# Altium schematic sheet nfsee.SchDoc
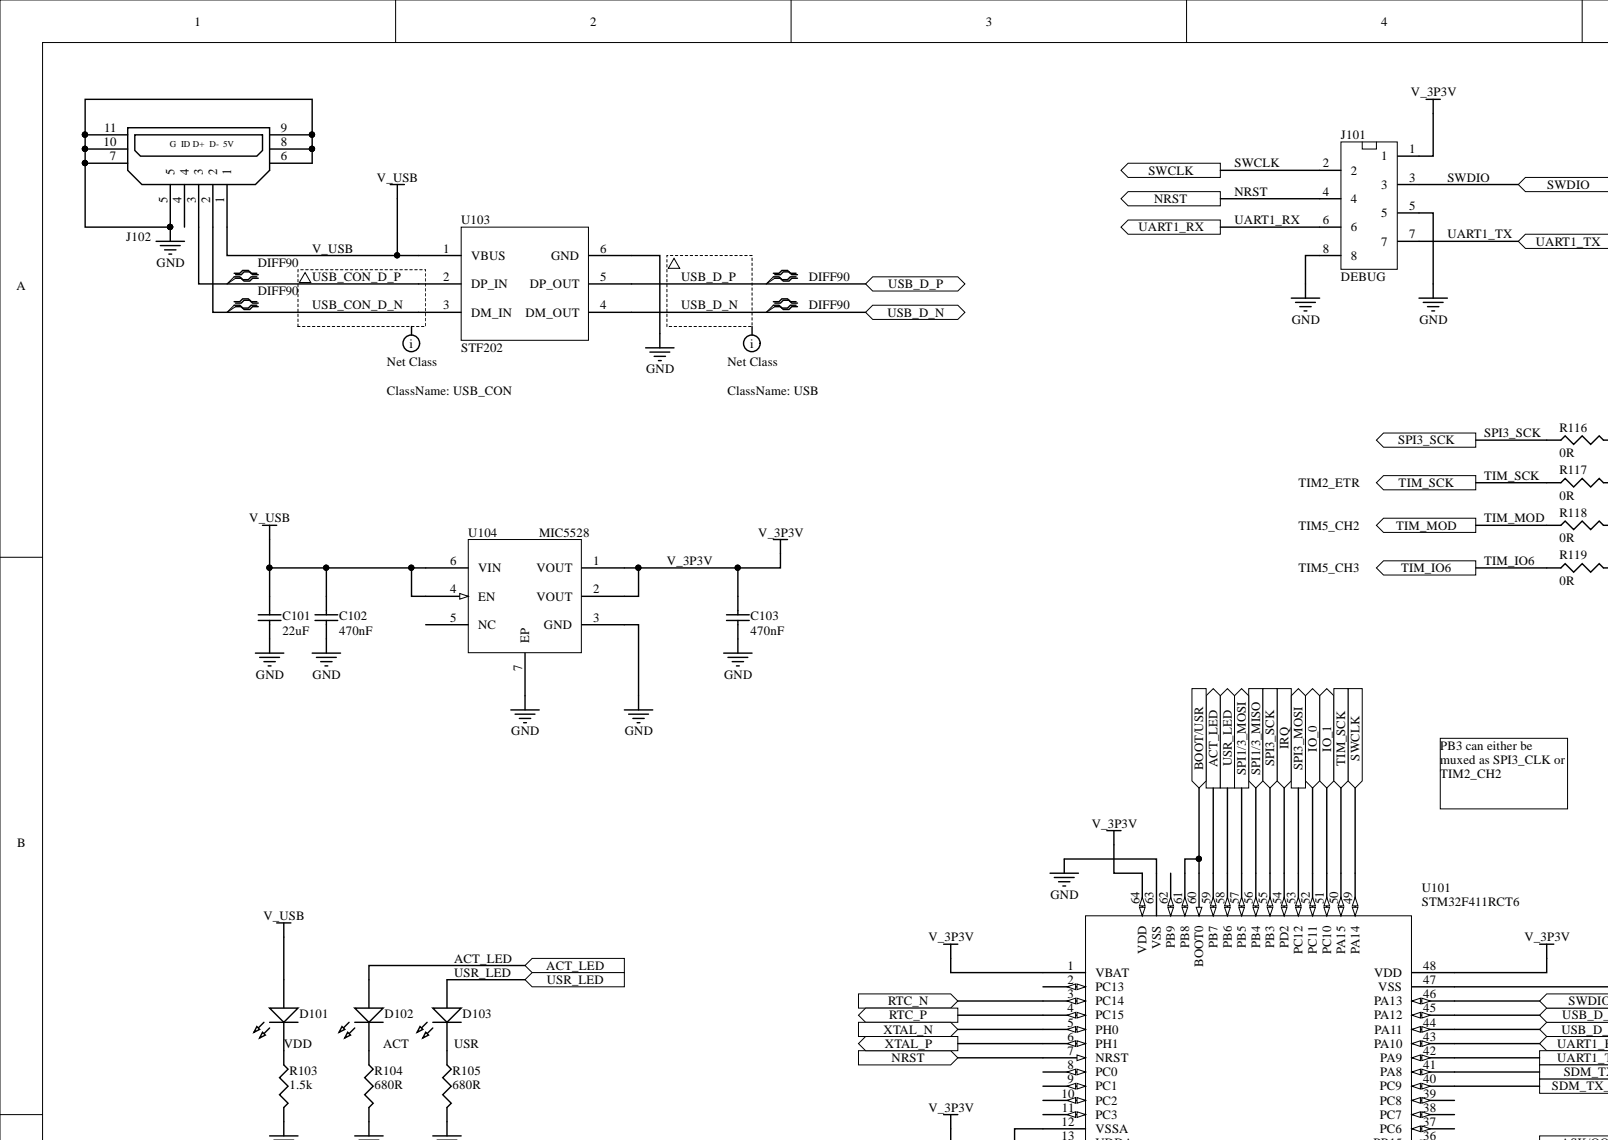
[diagram p0: nfsee.SchDoc, top left]
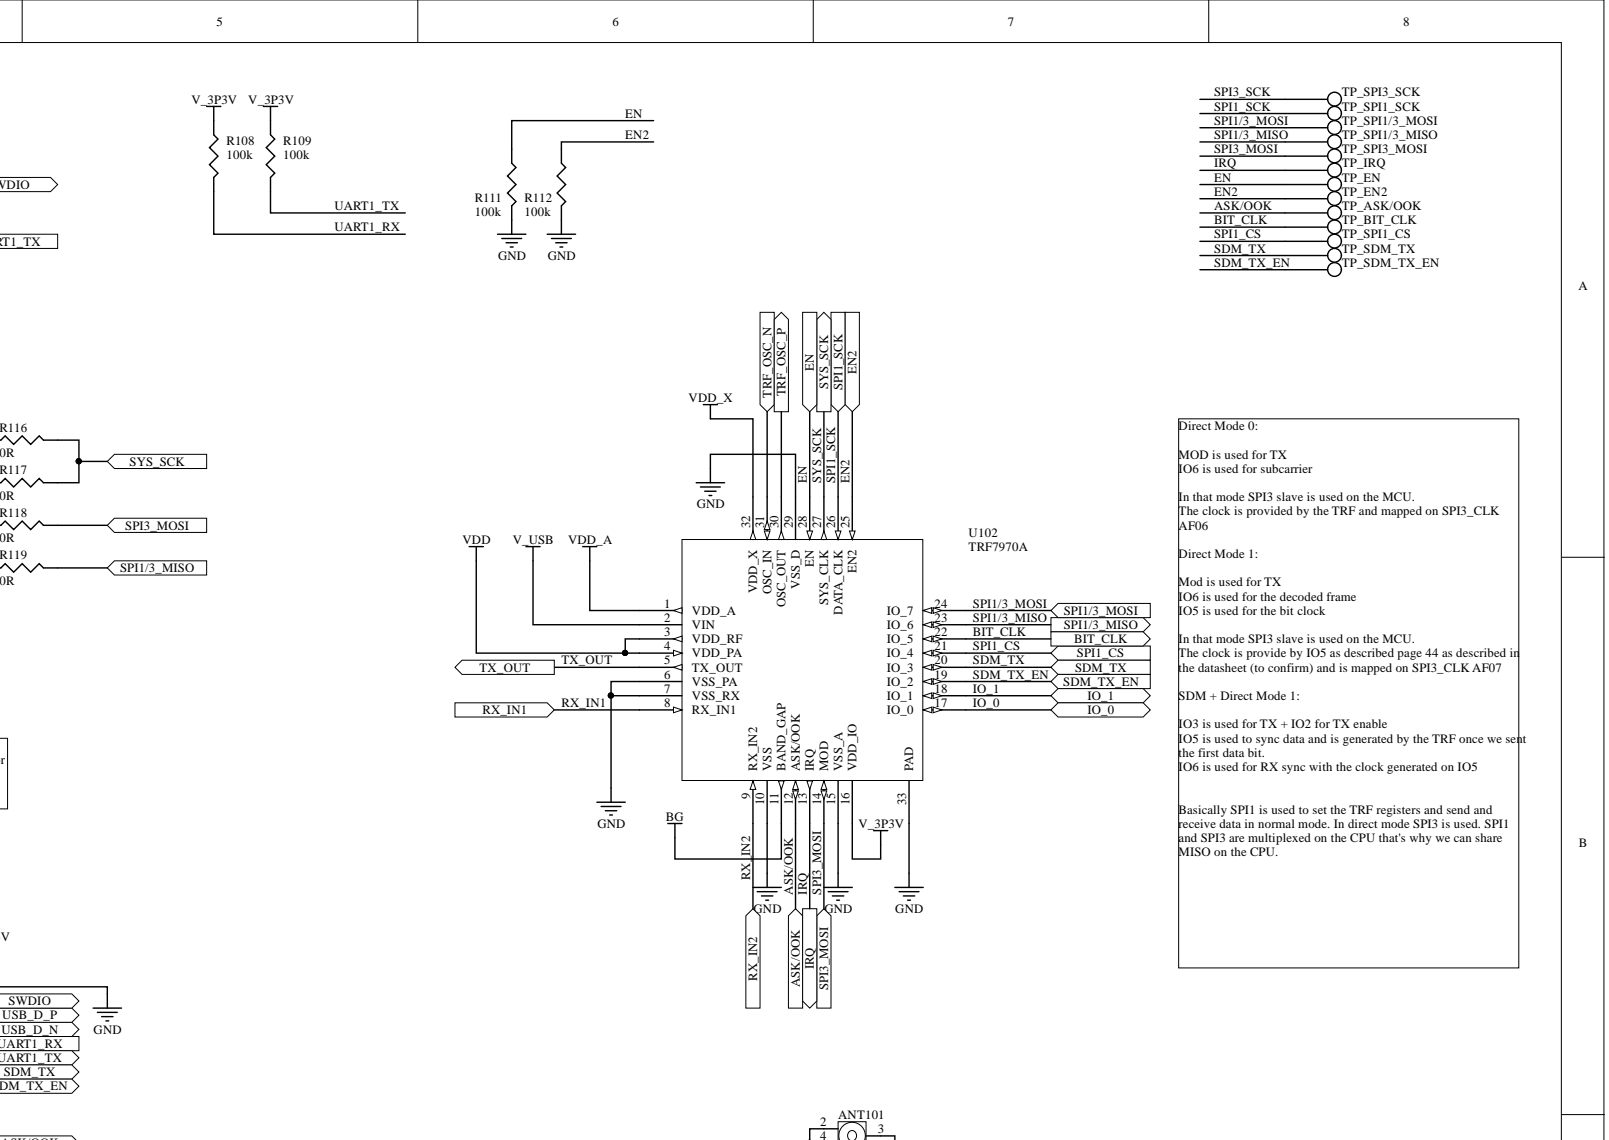
[diagram p1: nfsee.SchDoc, top right]
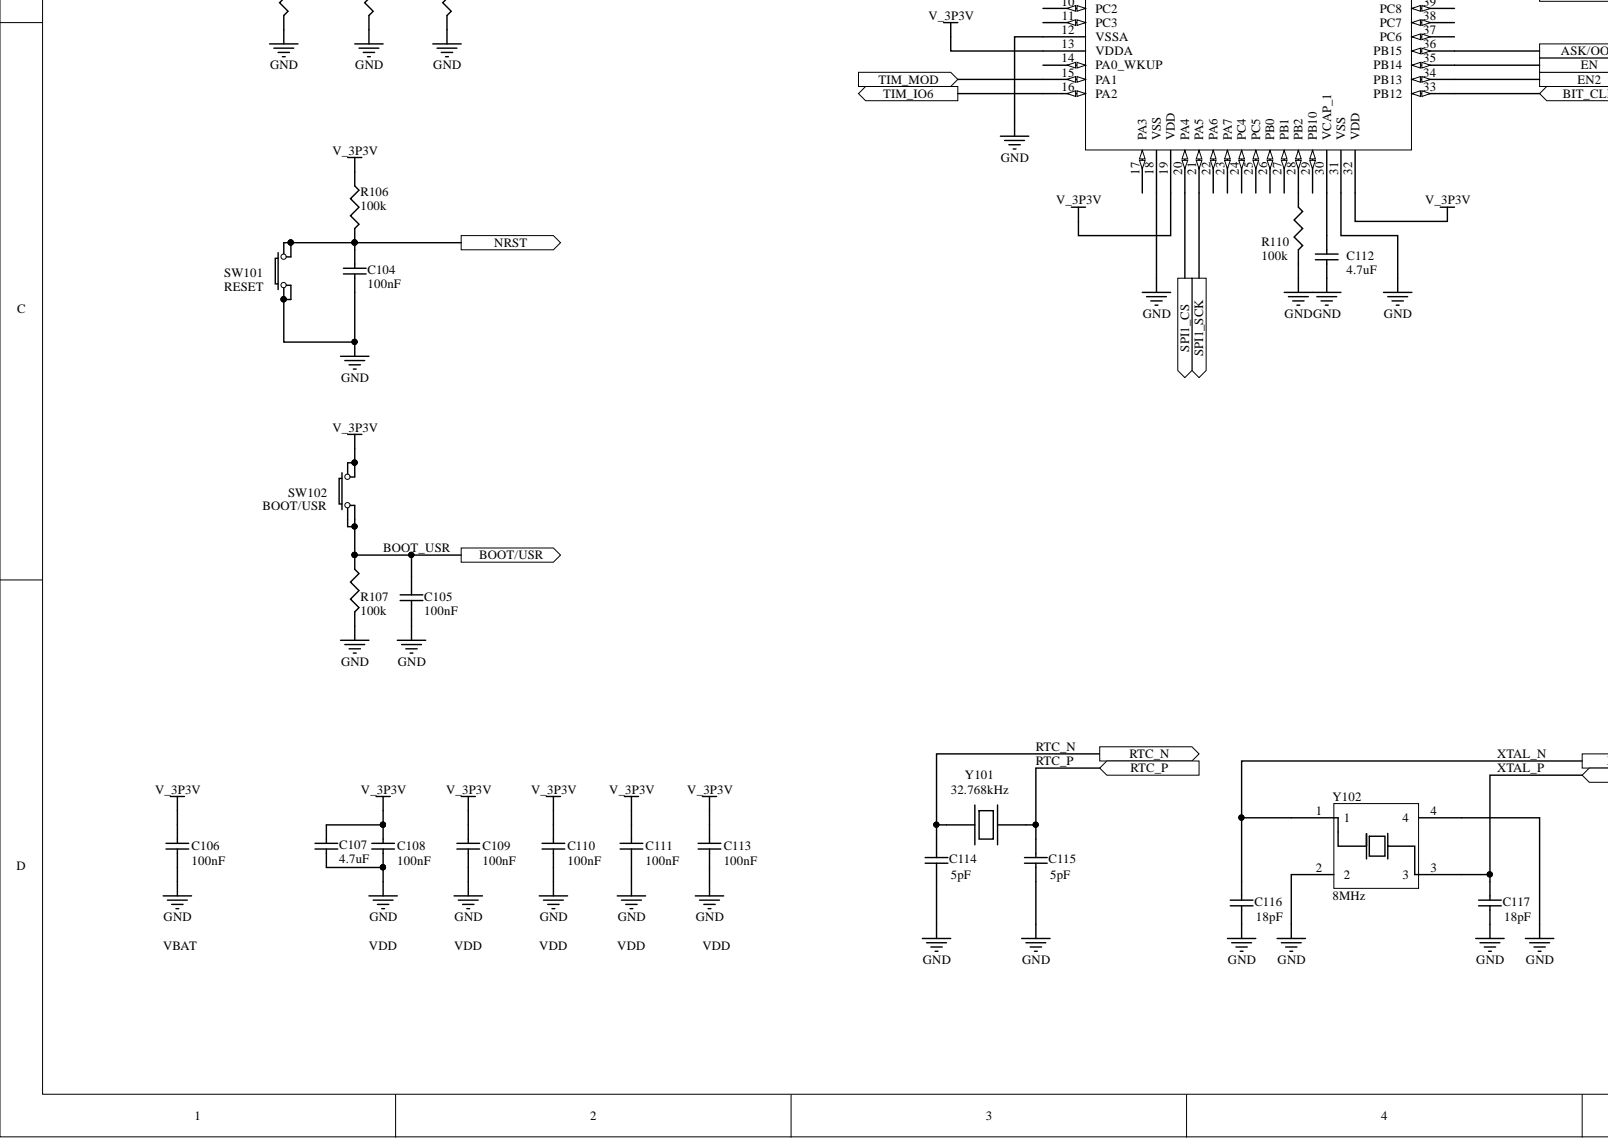
[diagram p2: nfsee.SchDoc, bottom left]
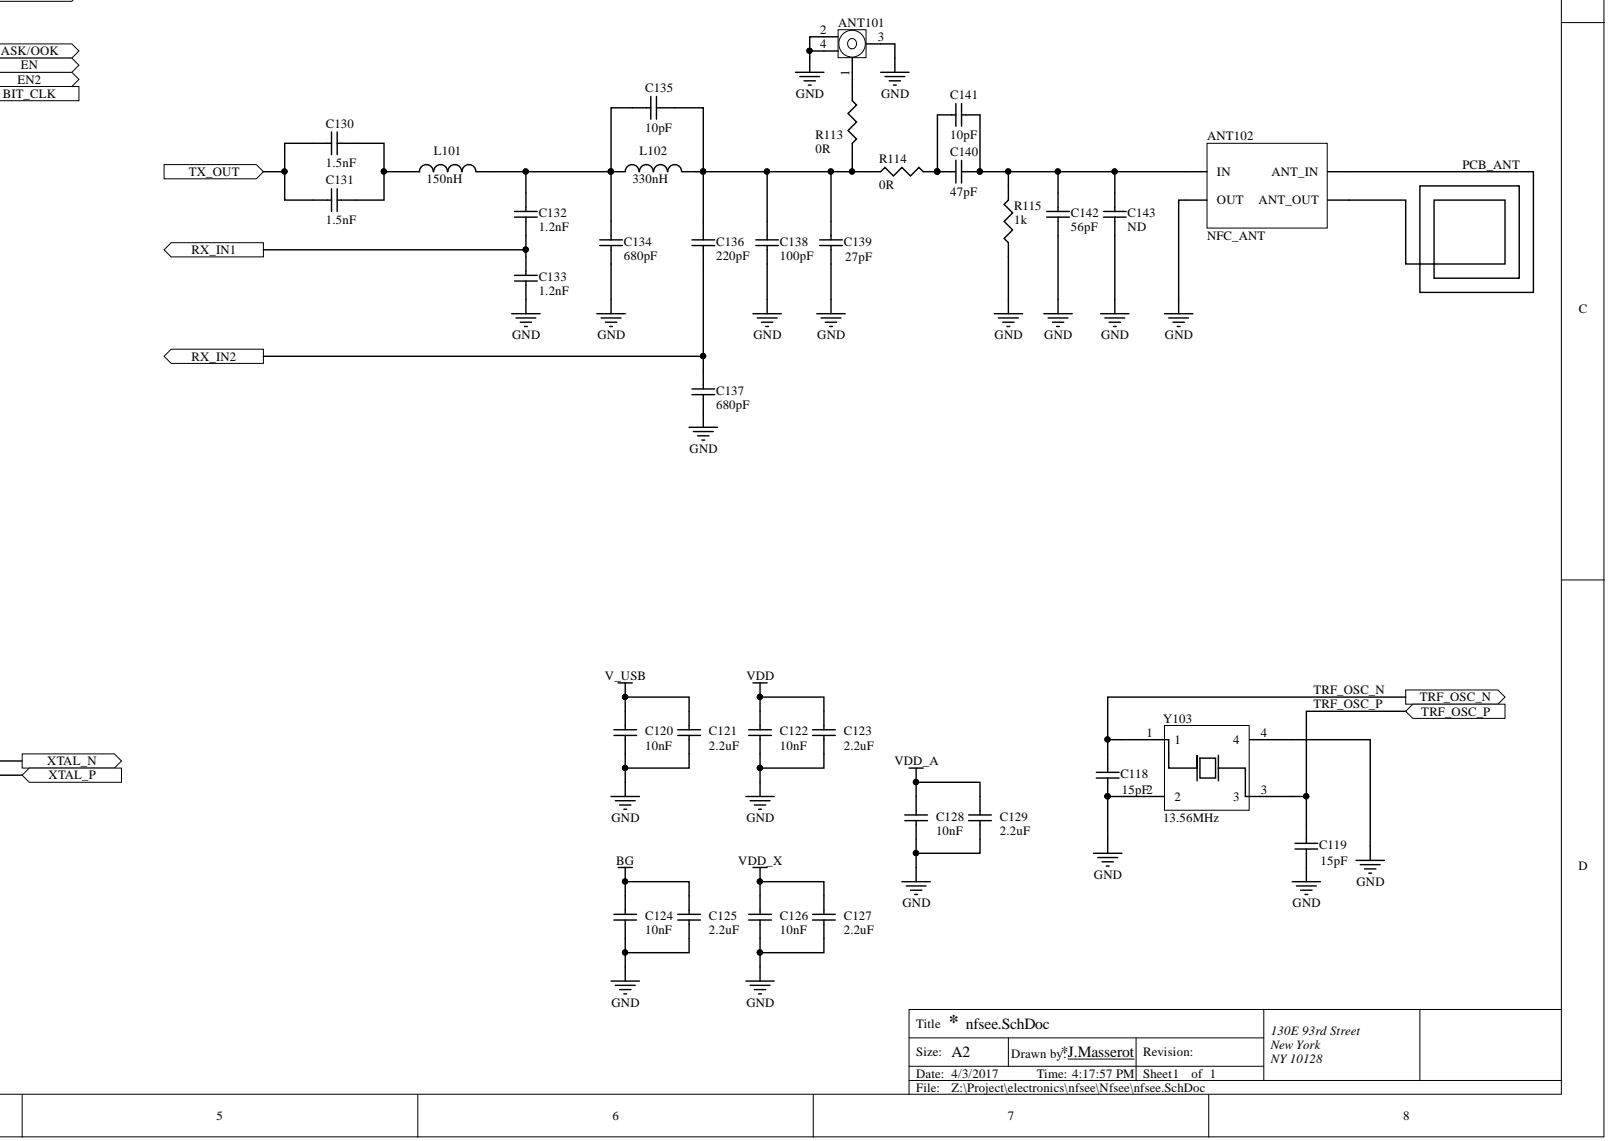
[diagram p3: nfsee.SchDoc, bottom right]

## Parts
ANT101  Antenna connector  [UFL_SMD]  (value not drawn)
ANT102  NFC_ANT  [NFC_ANT]
C101  22uF  [1608[0603]]
C102  470nF  [1608[0603]]
C103  470nF  [1608[0603]]
C104  100nF  [1608[0603]]
C105  100nF  [1608[0603]]
C106  100nF  [1608[0603]]
C107  4.7uF  [1608[0603]]
C108  100nF  [1608[0603]]
C109  100nF  [1608[0603]]
C110  100nF  [1608[0603]]
C111  100nF  [1608[0603]]
C112  4.7uF  [1608[0603]]
C113  100nF  [1608[0603]]
C114  5pF  [1608[0603]]
C115  5pF  [1608[0603]]
C116  18pF  [1608[0603]]
C117  18pF  [1608[0603]]
C118  15pF  [1608[0603]]
C119  15pF  [1608[0603]]
C120  10nF  [1608[0603]]
C121  2.2uF  [1608[0603]]
C122  10nF  [1608[0603]]
C123  2.2uF  [1608[0603]]
C124  10nF  [1608[0603]]
C125  2.2uF  [1608[0603]]
C126  10nF  [1608[0603]]
C127  2.2uF  [1608[0603]]
C128  10nF  [1608[0603]]
C129  2.2uF  [1608[0603]]
C130  1.5nF  [1608[0603]]
C131  1.5nF  [1608[0603]]
C132  1.2nF  [1608[0603]]
C133  1.2nF  [1608[0603]]
C134  680pF  [1608[0603]]
C135  10pF  [1608[0603]]
C136  220pF  [1608[0603]]
C137  680pF  [1608[0603]]
C138  100pF  [1608[0603]]
C139  27pF  [1608[0603]]
C140  47pF  [1608[0603]]
C141  10pF  [1608[0603]]
C142  56pF  [1608[0603]]
C143  ND  [1608[0603]]
D101  VDD  [J1-0603]
D102  ACT  [J1-0603]
D103  USR  [J1-0603]
J101  DEBUG  [MicroMaTch_8P]
J102  USB_micro  [MOLEX_47589-0001]  (value not drawn)
L101  150nH  [1608[0603]]
L102  330nH  [1608[0603]]
R103  1.5k  [J1-0603]
R104  680R  [J1-0603]
R105  680R  [J1-0603]
R106  100k  [J1-0603]
R107  100k  [J1-0603]
R108  100k  [J1-0603]
R109  100k  [J1-0603]
R110  100k  [J1-0603]
R111  100k  [J1-0603]
R112  100k  [J1-0603]
R113  0R  [J1-0603]
R114  0R  [J1-0603]
R115  1k  [J1-0603]
R116  0R  [J1-0603]
R117  0R  [J1-0603]
R118  0R  [J1-0603]
R119  0R  [J1-0603]
SW101  RESET  [KMR641NG]
SW102  BOOT/USR  [KMR641NG]
TP_ASK/OOK  Test point  [TP]  (value not drawn)
TP_BIT_CLK  Test point  [TP]  (value not drawn)
TP_EN  Test point  [TP]  (value not drawn)
TP_EN2  Test point  [TP]  (value not drawn)
TP_IRQ  Test point  [TP]  (value not drawn)
TP_SDM_TX  Test point  [TP]  (value not drawn)
TP_SDM_TX_EN  Test point  [TP]  (value not drawn)
TP_SPI1/3_MISO  Test point  [TP]  (value not drawn)
TP_SPI1/3_MOSI  Test point  [TP]  (value not drawn)
TP_SPI1_CS  Test point  [TP]  (value not drawn)
TP_SPI1_SCK  Test point  [TP]  (value not drawn)
TP_SPI3_MOSI  Test point  [TP]  (value not drawn)
TP_SPI3_SCK  Test point  [TP]  (value not drawn)
U101  STM32F411RCT6  [LQFP64]
U102  TRF7970A  [PVQFN-32N]
U103  STF202  [TSOP6]
U104  MIC5528  [DFN-6N]
Y101  32.768kHz  [XTAL2]
Y102  8MHz  [ABM3B]
Y103  13.56MHz  [CX2520DB]

## Ports
ACT_LED, ASK/OOK, BIT_CLK, BOOT/USR, EN, EN2, IO_0, IO_1, IRQ, NRST, RTC_N, RTC_P, RX_IN1, RX_IN2, SDM_TX, SDM_TX_EN, SPI1/3_MISO, SPI1/3_MOSI, SPI1_CS, SPI1_SCK, SPI3_MOSI, SPI3_SCK, SWCLK, SWDIO, SYS_SCK, TIM_IO6, TIM_MOD, TIM_SCK, TRF_OSC_N, TRF_OSC_P, TX_OUT, UART1_RX, UART1_TX, USB_D_N, USB_D_P, USR_LED, XTAL_N, XTAL_P

## Nets
BG: C124.2 C125.2 U102.11(BAND_GAP)
GND: ANT101.2 ANT101.3 ANT101.4 ANT102.2(OUT) C101.2 C102.2 C103.2 C104.1 C105.1 C106.1 C107.1 C108.1 C109.1 C110.1 C111.1 C112.1 C113.1 C114.1 C115.1 C116.1 C117.1 C118.1 C119.1 C120.1 C121.1 C122.1 C123.1 C124.1 C125.1 C126.1 C127.1 C128.1 C129.1 C133.2 C134.2 C137.2 C138.2 C139.1 C142.2 C143.2 J101.5 J101.8 J102.5 J102.6 J102.7 J102.8 J102.9 J102.10 J102.11 R103.1 R104.1 R105.1 R107.1 R110.1 R111.1 R112.1 R115.2 SW101.2 SW101.4 U101.12(VSSA) U101.18(VSS) U101.31(VSS) U101.47(VSS) U101.63(VSS) U102.6(VSS_PA) U102.7(VSS_RX) U102.10(VSS) U102.15(VSS_A) U102.29(VSS_D) U102.33(PAD) U103.6(GND) U104.3(GND) U104.7(EP) Y102.2 Y102.4 Y103.2 Y103.4
VDD: C122.2 C123.2 U102.3(VDD_RF) U102.4(VDD_PA)
VDD_A: C128.2 C129.2 U102.1(VDD_A)
VDD_X: C126.2 C127.2 U102.32(VDD_X)
ACT_LED: D102.1 U101.59(PB7) ; port ACT_LED
ASK/OOK: TP_ASK/OOK.1 U101.36(PB15) U102.12(ASK/OOK) ; port ASK/OOK
BIT_CLK: TP_BIT_CLK.1 U101.33(PB12) U102.22(IO_5) ; port BIT_CLK
BOOT_USR: C105.2 R107.2 SW102.2 SW102.4 U101.60(BOOT0) U101.61(PB8) ; port BOOT/USR
EN: R111.2 TP_EN.1 U101.35(PB14) U102.28(EN) ; port EN
EN2: R112.2 TP_EN2.1 U101.34(PB13) U102.25(EN2) ; port EN2
IO_0: U101.52(PC11) U102.17(IO_0) ; port IO_0
IO_1: U101.51(PC10) U102.18(IO_1) ; port IO_1
IRQ: TP_IRQ.1 U101.54(PD2) U102.13(IRQ) ; port IRQ
NRST: C104.2 J101.4 R106.1 SW101.1 SW101.3 U101.7(NRST) ; port NRST
PCB_ANT: ANT102.3(ANT_OUT) ANT102.4(ANT_IN)
RTC_N: C114.2 U101.3(PC14) Y101.1 ; port RTC_N
RTC_P: C115.2 U101.4(PC15) Y101.2 ; port RTC_P
RX_IN1: C132.2 C133.1 U102.8(RX_IN1) ; port RX_IN1
RX_IN2: C136.2 C137.1 U102.9(RX_IN2) ; port RX_IN2
SDM_TX: TP_SDM_TX.1 U101.41(PA8) U102.20(IO_3) ; port SDM_TX
SDM_TX_EN: TP_SDM_TX_EN.1 U101.40(PC9) U102.19(IO_2) ; port SDM_TX_EN
SPI1/3_MISO: R119.1 TP_SPI1/3_MISO.1 U101.56(PB4) U102.23(IO_6) ; port SPI1/3_MISO
SPI1/3_MOSI: TP_SPI1/3_MOSI.1 U101.57(PB5) U102.24(IO_7) ; port SPI1/3_MOSI
SPI1_CS: TP_SPI1_CS.1 U101.20(PA4) U102.21(IO_4) ; port SPI1_CS
SPI1_SCK: TP_SPI1_SCK.1 U101.21(PA5) U102.26(DATA_CLK) ; port SPI1_SCK
SPI3_MOSI: R118.1 TP_SPI3_MOSI.1 U101.53(PC12) U102.14(MOD) ; port SPI3_MOSI
SPI3_SCK: R116.2 TP_SPI3_SCK.1 U101.55(PB3) ; port SPI3_SCK
SWCLK: J101.2 U101.49(PA14) ; port SWCLK
SWDIO: J101.3 U101.46(PA13) ; port SWDIO
SYS_SCK: R116.1 R117.1 U102.27(SYS_CLK) ; port SYS_SCK
TIM_IO6: R119.2 U101.16(PA2) ; port TIM_IO6
TIM_MOD: R118.2 U101.15(PA1) ; port TIM_MOD
TIM_SCK: R117.2 U101.50(PA15) ; port TIM_SCK
TRF_OSC_N: C118.2 U102.31(OSC_IN) Y103.1 ; port TRF_OSC_N
TRF_OSC_P: C119.2 U102.30(OSC_OUT) Y103.3 ; port TRF_OSC_P
TX_OUT: C130.2 C131.2 U102.5(TX_OUT) ; port TX_OUT
UART1_RX: J101.6 R108.1 U101.43(PA10) ; port UART1_RX
UART1_TX: J101.7 R109.1 U101.42(PA9) ; port UART1_TX
USB_CON_D_N: J102.2 U103.3(DM_IN)
USB_CON_D_P: J102.3 U103.2(DP_IN)
USB_D_N: U101.44(PA11) U103.4(DM_OUT) ; port USB_D_N
USB_D_P: U101.45(PA12) U103.5(DP_OUT) ; port USB_D_P
USR_LED: D103.1 U101.58(PB6) ; port USR_LED
V_3P3V: C103.1 C106.2 C107.2 C108.2 C109.2 C110.2 C111.2 C113.2 J101.1 R106.2 R108.2 R109.2 SW102.1 SW102.3 U101.1(VBAT) U101.13(VDDA) U101.19(VDD) U101.32(VDD) U101.48(VDD) U101.64(VDD) U102.16(VDD_IO) U104.1(VOUT) U104.2(VOUT)
V_USB: C101.1 C102.1 C120.2 C121.2 D101.1 J102.1 U102.2(VIN) U103.1(VBUS) U104.4(EN) U104.6(VIN)
XTAL_N: C116.2 U101.5(PH0) Y102.1 ; port XTAL_N
XTAL_P: C117.2 U101.6(PH1) Y102.3 ; port XTAL_P
NetANT101_1: ANT101.1 R113.2
NetANT102_1: ANT102.1(IN) C140.1 C141.2 C142.1 C143.1 R115.1
NetC112_2: C112.2 U101.30(VCAP_1)
NetC130_1: C130.1 C131.1 L101.1
NetC132_1: C132.1 C134.1 C135.1 L101.2 L102.1
NetC135_2: C135.2 C136.1 C138.1 C139.2 L102.2 R113.1 R114.2
NetC140_2: C140.2 C141.1 R114.1
NetD101_2: D101.2 R103.2
NetD102_2: D102.2 R104.2
NetD103_2: D103.2 R105.2
NetR110_2: R110.2 U101.28(PB2)
N00010:
N00011:

Not connected: J102 4; U101 2(PC13) 8(PC0) 9(PC1) 10(PC2) 11(PC3) 14(PA0_WKUP) 17(PA3) 22(PA6) 23(PA7) 24(PC4) 25(PC5) 26(PB0) 27(PB1) 29(PB10) 37(PC6) 38(PC7) 39(PC8) 62(PB9); U104 5(NC)

## Library data of the parts
ANT101 = Antenna connector: Manf. Part Number: RECE-20279-001E-01; Manufacturer: Taoglas; Component Kind: Standard; Description: Antenna connector; Library Name: Connectors.IntLib; Package: U.FL SMD connector; Supplier: Mouser; Supplier Link: http://www.mouser.com/ProductDetail/Taoglas/RECE-20279-001E-01/?qs=%2fha2pyFadujqhFX9QSGQ0Jq8TQbSmm0TSdw7FzJZzJAdZFUpRzf0EieCCALjSPrt; Supplier Part Number: 960-RECE20279001E01
ANT102 = NFC_ANT: PCB NFC ANTENNA; Component Kind: Standard; Library Name: Misc.IntLib; Package: PCB ANTENNA
C101 = Cap Semi: CAP CERA 22uF X5R 6.3V 0603; Manf. Part Number: 06036D226MAT2A; Manufacturer: AVX; Component Kind: Standard; Library Name: Miscellaneous Devices.IntLib; Package: 0603; Signal Integrity: Cap Semi; Simulation: CAP; Supplier: Mouser; Supplier Link: http://www.mouser.com/ProductDetail/AVX/06036D226MAT2A/?qs=sGAEpiMZZMs0AnBnWHyRQKJCfzG%2fi9wH35CSYO9QB3%2fIceXkFkdrNw%3d%3d; Supplier Part Number: 581-06036D226MAT2A
C102 C103 = Cap Semi: CAP CERA 470nF X5R 16V 10% 0402; Manf. Part Number: UMK107ABJ474KA-T; Manufacturer: Taiyo Yuden; Component Kind: Standard; Library Name: Miscellaneous Devices.IntLib; Package: 0603; Signal Integrity: Cap Semi; Simulation: CAP; Supplier: Mouser; Supplier Link: http://www.mouser.com/ProductDetail/Taiyo-Yuden/UMK107ABJ474KA-T/?qs=sGAEpiMZZMs0AnBnWHyRQEHUpoJWdPZB6KfEGN7MI80%3d; Supplier Part Number: 963-UMK107ABJ474KA-T
C104-C106 C108-C111 C113 = Cap Semi: CAP CERA 100nF X7R 16V 10% 0402; Manf. Part Number: C0402C104K4RAC; Manufacturer: Kemet; Component Kind: Standard; Library Name: Miscellaneous Devices.IntLib; Package: 0603; Signal Integrity: Cap Semi; Simulation: CAP; Supplier: Mouser; Supplier Link: http://www.mouser.com/ProductDetail/KEMET/C0402C104K4RAC/?qs=sGAEpiMZZMs0AnBnWHyRQFv7x1xn%252bYFduMzvnPR0L28%3d; Supplier Part Number: 80-C0402C104K4RAC
C107 C112 = Cap Semi: CAP CERA 4.7uF X5R 6.3V 20% 0402; Manf. Part Number: 06036D475MAT4A; Manufacturer: AVX; Component Kind: Standard; Library Name: Miscellaneous Devices.IntLib; Package: 0603; Signal Integrity: Cap Semi; Simulation: CAP; Supplier: Mouser; Supplier Link: http://www.mouser.com/ProductDetail/AVX/06036D475MAT4A/?qs=sGAEpiMZZMs0AnBnWHyRQPSZir2U04Awc9%2fDG0hqmgc%3d; Supplier Part Number: 581-06036D475MAT4A
C114 C115 = Cap Semi: CAP CERA 5pF C0G 50V 5% 0402; Manf. Part Number: GRM1555C1H5R0CA01D; Manufacturer: Murata; Component Kind: Standard; Library Name: Miscellaneous Devices.IntLib; Package: 0603; Signal Integrity: Cap Semi; Simulation: CAP; Supplier: Mouser; Supplier Link: http://www.mouser.com/ProductDetail/Murata/GRM1555C1H5R0CA01D/?qs=%2fha2pyFaduiGTOITjt%2fF7w8kJ2ycxB0GeweqtdAyD6hHauaGy4SrPSD1iqnm1ZsC; Supplier Part Number: 81-GRM1555C1H5R0CA1D
C116 C117 = Cap Semi: CAP CERA 18pF C0G 16V 5% 0402; Manf. Part Number: GRM1885C1H180JA01D; Manufacturer: Murata; Component Kind: Standard; Library Name: Miscellaneous Devices.IntLib; Package: 0603; Signal Integrity: Cap Semi; Simulation: CAP; Supplier: Mouser; Supplier Link: http://www.mouser.com/ProductDetail/Murata-Electronics/GRM1885C1H180JA01D/?qs=sGAEpiMZZMs0AnBnWHyRQEzybnecWqjRUTJtHldbfgg%3d; Supplier Part Number: 81-GRM39C180J50
C118 C119 = Cap Semi: CAP CERA 15pF C0G 50V 5% 0603; Manf. Part Number: VJ0603A150JXACW1BC; Manufacturer: Vishay; Component Kind: Standard; Library Name: Miscellaneous Devices.IntLib; Package: 0603; Signal Integrity: Cap Semi; Simulation: CAP; Supplier: Mouser; Supplier Link: http://www.mouser.com/ProductDetail/Vishay/VJ0603A150JXACW1BC/?qs=%2fha2pyFadujyp16hEvN9k1tTARPA1dk3NW8q4AVb1Cq2DteBzrHlmA%3d%3d; Supplier Part Number: 77-VJ0603A150JXACBC
C120 C122 C124 C126 C128 = Cap Semi: CAP CERA 10nF 16V 10% 0603; Manf. Part Number: 885012206040; Manufacturer: Wurth Electronics; Component Kind: Standard; Library Name: Miscellaneous Devices.IntLib; Package: 0603; Signal Integrity: Cap Semi; Simulation: CAP; Supplier: Mouser; Supplier Link: http://www.mouser.com/ProductDetail/Wurth-Electronics/885012206040/?qs=%2fha2pyFaduhWLwq%252bImdxjNLfXvBlNiamf3gc1l1OXmS75nuOaleIcQ%3d%3d; Supplier Part Number: 710-885012206040
C121 C123 C125 C127 C129 = Cap Semi: CAP CERA 2.2uF X5R 10V 10% 0603; Manf. Part Number: 0603ZD225KAT4A; Manufacturer: AVX; Component Kind: Standard; Library Name: Miscellaneous Devices.IntLib; Package: 0603; Signal Integrity: Cap Semi; Simulation: CAP; Supplier: Mouser; Supplier Link: http://www.mouser.com/ProductDetail/AVX/0603ZD225KAT4A/?qs=sGAEpiMZZMs0AnBnWHyRQAwkDkDzLNak4GjhHRoZ8KI%3d; Supplier Part Number: 581-0603ZD225KAT4A
C130 C131 = Cap Semi: CAP CERA 1.5nFF X7R 10V 10% 0603; Manf. Part Number: GRM033R71A152KA01D; Manufacturer: Murata; Component Kind: Standard; Library Name: Miscellaneous Devices.IntLib; Package: 0603; Signal Integrity: Cap Semi; Simulation: CAP; Supplier: Mouser; Supplier Link: http://www.mouser.com/ProductDetail/Murata/GRM033R71A152KA01D/?qs=%2fha2pyFaduiG1IarW8zyNVwH0x1%2fIqOvfu9hhE4wLhyFTwxTPkya%2fw%3d%3d; Supplier Part Number: 81-GRM033R71A152KA1D
C132 C133 = Cap Semi: CAP CERA 1.2nF X7R 50V 10% 0603; Manf. Part Number: VJ0603Y122KXACW1BC; Manufacturer: Vishay; Component Kind: Standard; Library Name: Miscellaneous Devices.IntLib; Package: 0603; Signal Integrity: Cap Semi; Simulation: CAP; Supplier: Mouser; Supplier Link: http://www.mouser.com/ProductDetail/Vishay/VJ0603Y122KXACW1BC/?qs=%2fha2pyFaduidGoO0X7aK7bwGCmyC7zJl56NPA7WoosGf45aYZhrPgQ%3d%3d; Supplier Part Number: 77-VJ0603Y122KXACBC
C134 C137 = Cap Semi: CAP CERA 680pF X7R 10V 10% 0603; Manf. Part Number: 885012206007; Manufacturer: Wurth Electronics; Component Kind: Standard; Library Name: Miscellaneous Devices.IntLib; Package: 0603; Signal Integrity: Cap Semi; Simulation: CAP; Supplier: Mouser; Supplier Link: http://www.mouser.com/ProductDetail/Wurth-Electronics/885012206007/?qs=%2fha2pyFaduhWLwq%252bImdxjLO%2fv8xGiI%2fsKhimoUq1iPVwN9w4XhRqKA%3d%3d; Supplier Part Number: 710-885012206007
C135 C141 = Cap Semi: CAP CERA 10pF C0G 50V 5% 0603; Manf. Part Number: VJ0603A100JXACW1BC; Manufacturer: Vishay; Component Kind: Standard; Library Name: Miscellaneous Devices.IntLib; Package: 0603; Signal Integrity: Cap Semi; Simulation: CAP; Supplier: Mouser; Supplier Link: http://www.mouser.com/ProductDetail/Vishay/VJ0603A100JXACW1BC/?qs=%2fha2pyFadujyp16hEvN9k5B9eezEQJF5%252bjZdQ1fPzcIoqfMEEff%2foA%3d%3d; Supplier Part Number: 77-VJ0603A100JXACBC
C136 = Cap Semi: CAP CERA 220pF X7R 25V 10% 0603; Manf. Part Number: 885012206055; Manufacturer: Wurth Electronics; Component Kind: Standard; Library Name: Miscellaneous Devices.IntLib; Package: 0603; Signal Integrity: Cap Semi; Simulation: CAP; Supplier: Mouser; Supplier Link: http://www.mouser.com/ProductDetail/Wurth-Electronics/885012206055/?qs=%2fha2pyFaduhWLwq%252bImdxjNq2n7QzxvGHMbRWxVtnD035qV4wPi%2fggA%3d%3d; Supplier Part Number: 710-885012206055
C138 = Cap Semi: CAP CERA 100pF X7R 10V 10% 0603; Manf. Part Number: 885012206003; Manufacturer: Wurth Electronics; Component Kind: Standard; Library Name: Miscellaneous Devices.IntLib; Package: 0603; Signal Integrity: Cap Semi; Simulation: CAP; Supplier: Mouser; Supplier Link: http://www.mouser.com/ProductDetail/Wurth-Electronics/885012206003/?qs=%2fha2pyFaduhWLwq%252bImdxjGOZu7LVA7W6zWz1H4ZT5n6%252bVh2V4hDr9A%3d%3d; Supplier Part Number: 710-885012206003
C139 = Cap Semi: CAP CERA 27pF C0G 50V 1% 0603; Manf. Part Number: 06035A270FAT2A; Manufacturer: AVX; Component Kind: Standard; Library Name: Miscellaneous Devices.IntLib; Package: 0603; Signal Integrity: Cap Semi; Simulation: CAP; Supplier: Mouser; Supplier Link: http://www.mouser.com/ProductDetail/AVX/06035A270FAT2A/?qs=sGAEpiMZZMs0AnBnWHyRQE2IRmxFPR1er9tgYjji9i4%3d; Supplier Part Number: 581-06035A270FAT2A
C140 = Cap Semi: CAP CERA 47pF NP0 25V 10%; Manf. Part Number: 06033A470K4T2A; Manufacturer: AVX; Component Kind: Standard; Library Name: Miscellaneous Devices.IntLib; Package: 0603; Signal Integrity: Cap Semi; Simulation: CAP; Supplier: Mouser; Supplier Link: http://www.mouser.com/ProductDetail/AVX/06033A470K4T2A/?qs=%2fha2pyFadugsIdtCwim6HN5k1R5T017J1LQzhyXDr1Qz0%2fwrTiWl4Q%3d%3d; Supplier Part Number: 581-06033A470K4T2A
C142 = Cap Semi: CAP CERA 56pF C0G 50V 5%; Manf. Part Number: VJ0603A560JXACW1BC; Manufacturer: Vishay; Component Kind: Standard; Library Name: Miscellaneous Devices.IntLib; Package: 0603; Signal Integrity: Cap Semi; Simulation: CAP; Supplier: Mouser; Supplier Link: http://www.mouser.com/ProductDetail/Vishay/VJ0603A560JXACW1BC/?qs=%2fha2pyFaduiC%252bdqC1cx0IITSxoVSQxFtPrhjvad0Vfi%2fu2s8UzjNgg%3d%3d; Supplier Part Number: 77-VJ0603A560JXACBC
C143 = Cap Semi: CAP CERA; Component Kind: Standard; Library Name: Miscellaneous Devices.IntLib; Package: 0603; Signal Integrity: Cap Semi; Simulation: CAP
D101-D103 = LED0: LED GREEN VF 2.4 20mA; Manf. Part Number: LG Q396-PS-35; Manufacturer: Osram; Component Kind: Standard; Library Name: Miscellaneous Devices.IntLib; Package: 0603; Simulation: LED0; Supplier: Mouser; Supplier Link: http://www.mouser.com/ProductDetail/Osram-Opto-Semiconductor/LG-Q396-PS-35/?qs=%2fha2pyFadugClEKtvXtDivy6UviA3y7cTzuayU58mBDAh4R3ePN03g%3d%3d; Supplier Part Number: 720-LGQ396A3964
J101 = MicroMatch_8P: MicroMatch 8 pos; Manf. Part Number: 7-188275-8; Manufacturer: TE; Component Kind: Standard; Library Name: Connectors.IntLib; Package: SMD; Supplier: Mouser; Supplier Link: http://www.mouser.com/ProductDetail/TE-Connectivity-AMP/7-188275-8/?qs=sGAEpiMZZMvlX3nhDDO4ANz%2fm2yyltKRjZekLzu2SX0%3d; Supplier Part Number: 571-7-188275-8
J102 = USB_micro: Manf. Part Number: 47589-0001; Manufacturer: MOLEX; Component Kind: Standard; Library Name: Connectors.IntLib; Package: SMD/SMT; Supplier: Mouser; Supplier Link: http://www.mouser.com/ProductDetail/Molex/47589-0001/?qs=sGAEpiMZZMvacfJXZtNRX74UdXy3u7bt; Supplier Part Number: 538-47589-0001
L101 = Inductor: IND 150nH 5% 200mA 0603; Manf. Part Number: MLF1608A1R5JTD25; Manufacturer: TDK; Component Kind: Standard; Library Name: Miscellaneous Devices.IntLib; Package: 0603; Signal Integrity: Inductor; Simulation: INDUCTOR; Supplier: Mouser; Supplier Link: http://www.mouser.com/ProductDetail/TDK/MLF1608A1R5JTD25/?qs=%2fha2pyFadui4lOjd9PWDtlqrY2aQG5qZkeuxf6zNZ2%252bhcAuUMPciyg%3d%3d; Supplier Part Number: 810-MLF1608A1R5JTD25
L102 = Inductor: IND 330nH 5% 100mA 0603; Manf. Part Number: MLG1608SR33J; Manufacturer: TDK; Component Kind: Standard; Library Name: Miscellaneous Devices.IntLib; Package: 0603; Signal Integrity: Inductor; Simulation: INDUCTOR; Supplier: Mouser; Supplier Link: http://www.mouser.com/ProductDetail/TDK/MLG1608SR33J/?qs=sGAEpiMZZMsg%252by3WlYCkU3r0sTv6v5bp4FlgZnPd59A%3d; Supplier Part Number: 810-MLG1608SR33J
R103 = Res3: RES 1.5k 1% 0603; Manf. Part Number: CRCW02011K50JNED; Manufacturer: Vishay; Component Kind: Standard; Library Name: Miscellaneous Devices.IntLib; Package: 0603; Signal Integrity: Res; Simulation: RESISTOR; Supplier: Mouser; Supplier Link: http://www.mouser.com/ProductDetail/Vishay/CRCW02011K50JNED/?qs=%2fha2pyFaduixR2fLazFqVepmSnN6%2fDQFymJOaSMCjBx48WktmdDXmA%3d%3d; Supplier Part Number: 71-CRCW0201J-1.5K
R104 R105 = Res3: RES 680R 1% 0603; Manf. Part Number: CRCW0603680RFKEA; Manufacturer: Vishay; Component Kind: Standard; Library Name: Miscellaneous Devices.IntLib; Package: 0603; Signal Integrity: Res; Simulation: RESISTOR; Supplier: Mouser; Supplier Link: http://www.mouser.com/ProductDetail/Vishay/CRCW0603680RFKEA/?qs=sGAEpiMZZMu61qfTUdNhG7Gzy2l2IcrzddXesQEh6pk%3d; Supplier Part Number: 71-CRCW0603-680-E3
R106-R112 = Res3: RES 100k 5% 0603; Manf. Part Number: RCA0603100KJNEC; Manufacturer: Vishay; Component Kind: Standard; Library Name: Miscellaneous Devices.IntLib; Package: 0603; Signal Integrity: Res; Simulation: RESISTOR; Supplier: Mouser; Supplier Link: http://www.mouser.com/ProductDetail/Vishay/RCA0603100KJNEC/?qs=%2fha2pyFadug9vt83A1byEVRrpa7dDrydVvY1V5XwRjjQMC1POmdAww%3d%3d; Supplier Part Number: 71-RCA0603100KJNEC
R113 R114 R116-R119 = Res3: RES 0R 1% 0603; Manf. Part Number: ERJ-3GEY0R00V; Manufacturer: Panasonic; Component Kind: Standard; Library Name: Miscellaneous Devices.IntLib; Package: 0603; Signal Integrity: Res; Simulation: RESISTOR; Supplier: Mouser; Supplier Link: http://www.mouser.com/ProductDetail/Panasonic/ERJ-3GEY0R00V/?qs=%2fha2pyFadugUp37UjO2jw1knUa4j31ii7kR9S8ONBLJiOo%252bv0q9gtA%3d%3d; Supplier Part Number: 667-ERJ-3GEY0R00V
R115 = Res3: RES 1k 1% 0603; Manf. Part Number: CRCW06031K00FKEA; Manufacturer: Vishay; Component Kind: Standard; Library Name: Miscellaneous Devices.IntLib; Package: 0603; Signal Integrity: Res; Simulation: RESISTOR; Supplier: Mouser; Supplier Link: http://www.mouser.com/ProductDetail/Vishay/CRCW06031K00FKEA/?qs=sGAEpiMZZMtlubZbdhIBIIDYYWLC5QWgFXAApV6iVKs%3d; Supplier Part Number: 71-CRCW0603-1.0K-E3
SW101 SW102 = SWITCH_2: SWITCH, TACTILE, SPST-NO, 50mA, SMD; Manufacturer: C&K; Component Kind: Standard; Library Name: Misc.IntLib; Manf. Part Number: KMR641NG; Package: SMD/SMT; Supplier: Mouser; Supplier Link: http://www.mouser.com/ProductDetail/CK-Components/KMR641NG-ULC-LFS/?qs=%2fha2pyFaduh0p7wT%2fIpKGZcBAQiO87MNxooxrDdNjhM%3d; Supplier Part Number: 611-KMR641NGULCLFS
TP_ASK/OOK TP_BIT_CLK TP_EN TP_EN2 TP_IRQ TP_SDM_TX TP_SDM_TX_EN TP_SPI1/3_MISO TP_SPI1/3_MOSI TP_SPI1_CS TP_SPI1_SCK TP_SPI3_MOSI TP_SPI3_SCK = Touch point: Component Kind: Standard; Description: Test point; Library Name: Misc.IntLib
U101 = STM32F411RCT6: ARM Microcontrollers - MCU STM32 Dyn Eff MCU 256 K 100 MHz CPU; Manufacturer: ST; Component Kind: Standard; Library Name: IC.IntLib; Manf. Part Number: STM32F411RCT6; Package: LQFP-64; Supplier: Mouser; Supplier Link: http://www.mouser.com/ProductDetail/STMicroelectronics/STM32F411RCT6/?qs=%2fha2pyFadujxcn39gZUbxVtMFD%2fcoTlsIQk%2f%252bP0ZA3gOnm7I0MeXTw%3d%3d; Supplier Part Number: 511-STM32F411RCT6
U102 = TRF7970A: Multiprotocol Fully Integrated 13.56-MHz RFID and Near Field Communication  (NFC) Transceiver IC; Manf. Part Number: TRF7970ARHBR; Manufacturer: TI; Component Kind: Standard; Library Name: IC.IntLib; Package: VQFN-32; Supplier: Mouser; Supplier Link: http://www.mouser.com/ProductDetail/Texas-Instruments/TRF7970ARHBR/?qs=sGAEpiMZZMvQHWqrh58LgTfHkh4MMmPXotGK4aS7beI%3d; Supplier Part Number: 595-TRF7970ARHBR
U103 = STF202: USB Filter with ESD  Protection; Manf. Part Number: STF202-22T1G; Manufacturer: ON Semi; Component Kind: Standard; Library Name: IC.IntLib; Package: TSOP-6; Supplier: Mouser; Supplier Link: http://www.mouser.com/ProductDetail/ON-Semiconductor/STF202-22T1G/?qs=%2fha2pyFadujRFaXPnV9RsTetlaFPPaXSz4EERle60zyiot5T0fRtTg%3d%3d; Supplier Part Number: 511-STM32F411RCT6
U104 = MIC5528: 3.3V, 500A, Low-Voltage, Low-Dropout Regulator; Manf. Part Number: MIC5528-3.3YMT; Manufacturer: Microchip; Component Kind: Standard; Package: TDFN-6; Supplier: Mouser; Supplier Link: http://www.mouser.com/ProductDetail/Microchip-Technology-Micrel/MIC5528-33YMT-TR/?qs=sGAEpiMZZMsGz1a6aV8DcNranXV84HMLabvM9ds%2f6%252bc%3d; Supplier Part Number: 998-MIC5528-3.3YMTTR; Library Name: Powers.IntLib
Y101 = ABS07-32.768KHZ: CRYSTAL 32.768KHz 6pF 20PPM SMD; Manf. Part Number: ABS07-120-32.768kHz-T; Manufacturer: Abracon; Component Kind: Standard; Library Name: Misc.IntLib; Package: SMD/SMT; Supplier: Mouser; Supplier Link: http://www.mouser.com/ProductDetail/ABRACON/ABS07-120-32768kHz-T/?qs=%2fha2pyFadujPcmOfQpjQ%252bVA2EKtsluzx9it6%2fzxAShUZHDu5I7oqZsKdy5DB9VBz; Supplier Part Number: 815-ABS0712032.768KT
Y102 = ABM3B-8.000MHZ-B2-T: CRYSTAL 8MHZ 18PF SMD; Manufacturer: Abracon; Component Kind: Standard; Library Name: Misc.IntLib; Manf. Part Number: ABM3B-8.000MHZ-B2-T; Package: SMD/SMT; Supplier: Mouser; Supplier Link: http://www.mouser.com/ProductDetail/ABRACON/ABM3B-8000MHZ-B2-T/?qs=%2fha2pyFaduji7NL2VAos562EZje1%252bgIMKWxOcp2Dbkt7as9m11EZweSXFCl7PBOc; Supplier Part Number: 815-ABM3B-8.0-B2-T
Y103 = ABM3B-8.000MHZ-B2-T: CRYSTAL 13.56MHZ 12PF SMD; Manf. Part Number: CX2520DB13560H0FLJC1; Manufacturer: AVX; Component Kind: Standard; Library Name: Misc.IntLib; Package: SMD/SMT; Supplier: Mouser; Supplier Link: http://www.mouser.com/ProductDetail/AVX/CX2520DB13560H0FLJC1/?qs=%2fha2pyFaduiKYWBq4wWUkSYmsYpH%252bUPjFKni%2f3G4nf7GNyyHZgi0qSCjuUfisCAZ; Supplier Part Number: 581-CX2520DB13560HLJ
